# Altium schematic sheet VoltageSensorBoard.SchDoc(VoltageSensorBoard) (continued)
## Library data of the parts (continued)
*1 = TitleBlockWEMPEC: Library Name: SensorCard.SchLib; Component Kind: Standard
